# Altium schematic sheet CPU.SchDoc(CPU)
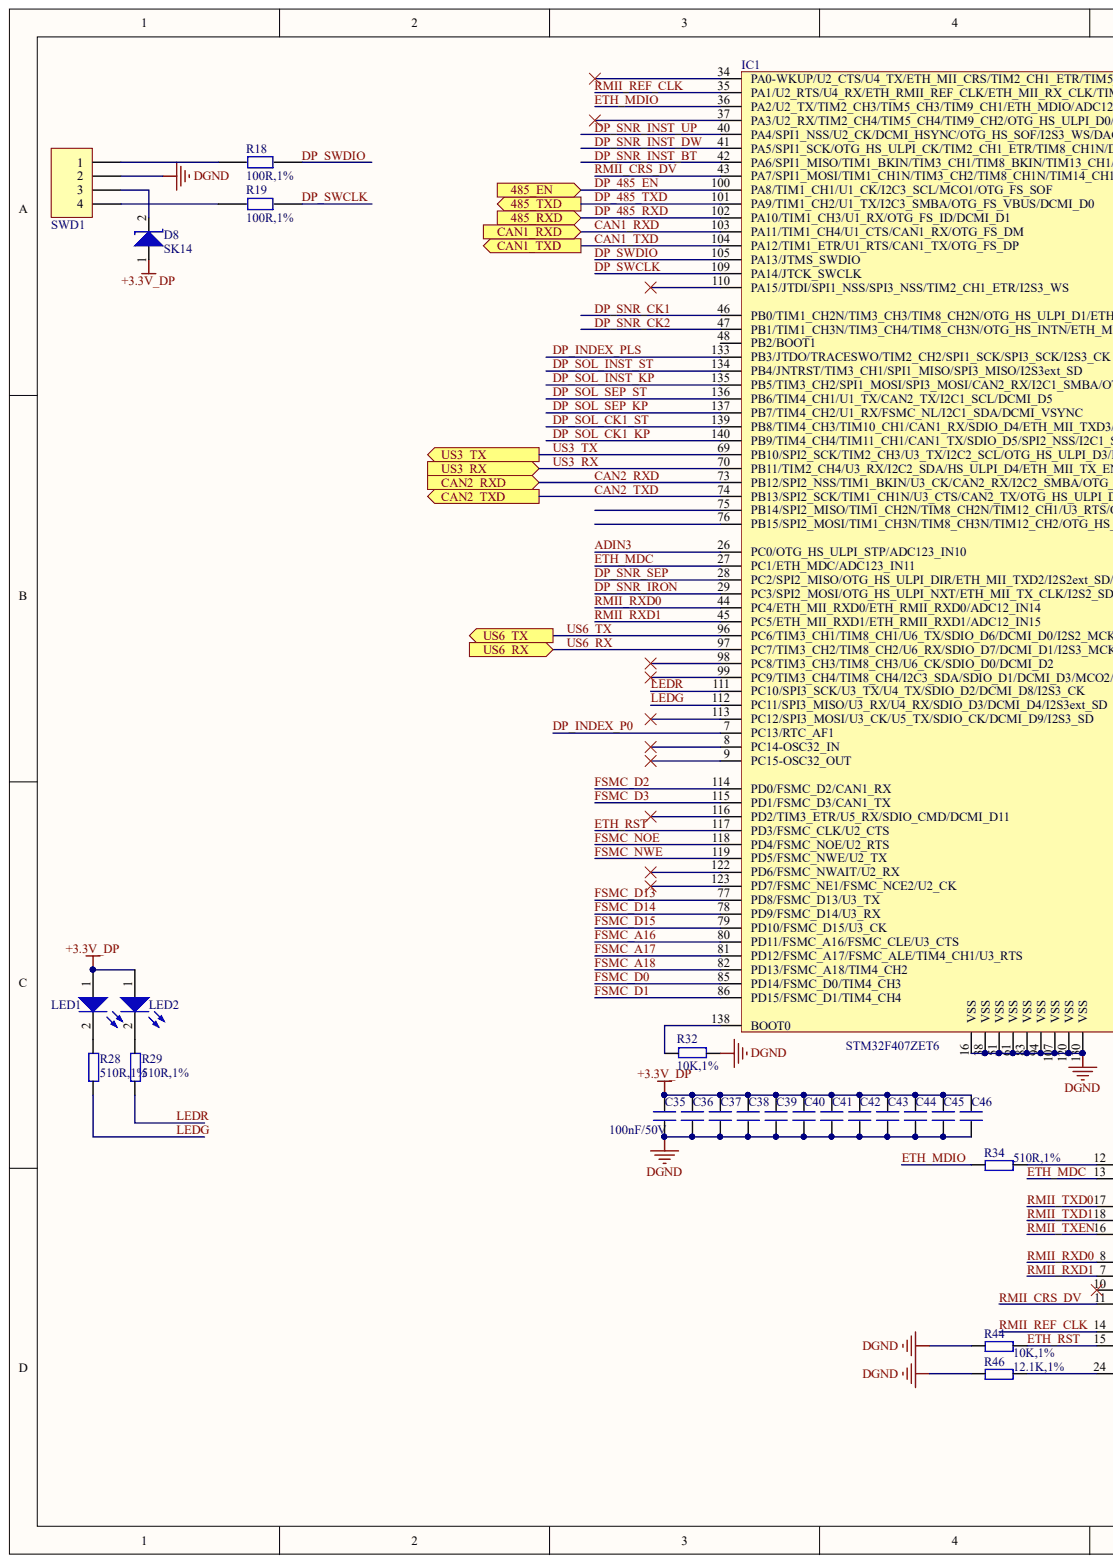
[diagram p0: CPU.SchDoc, left]
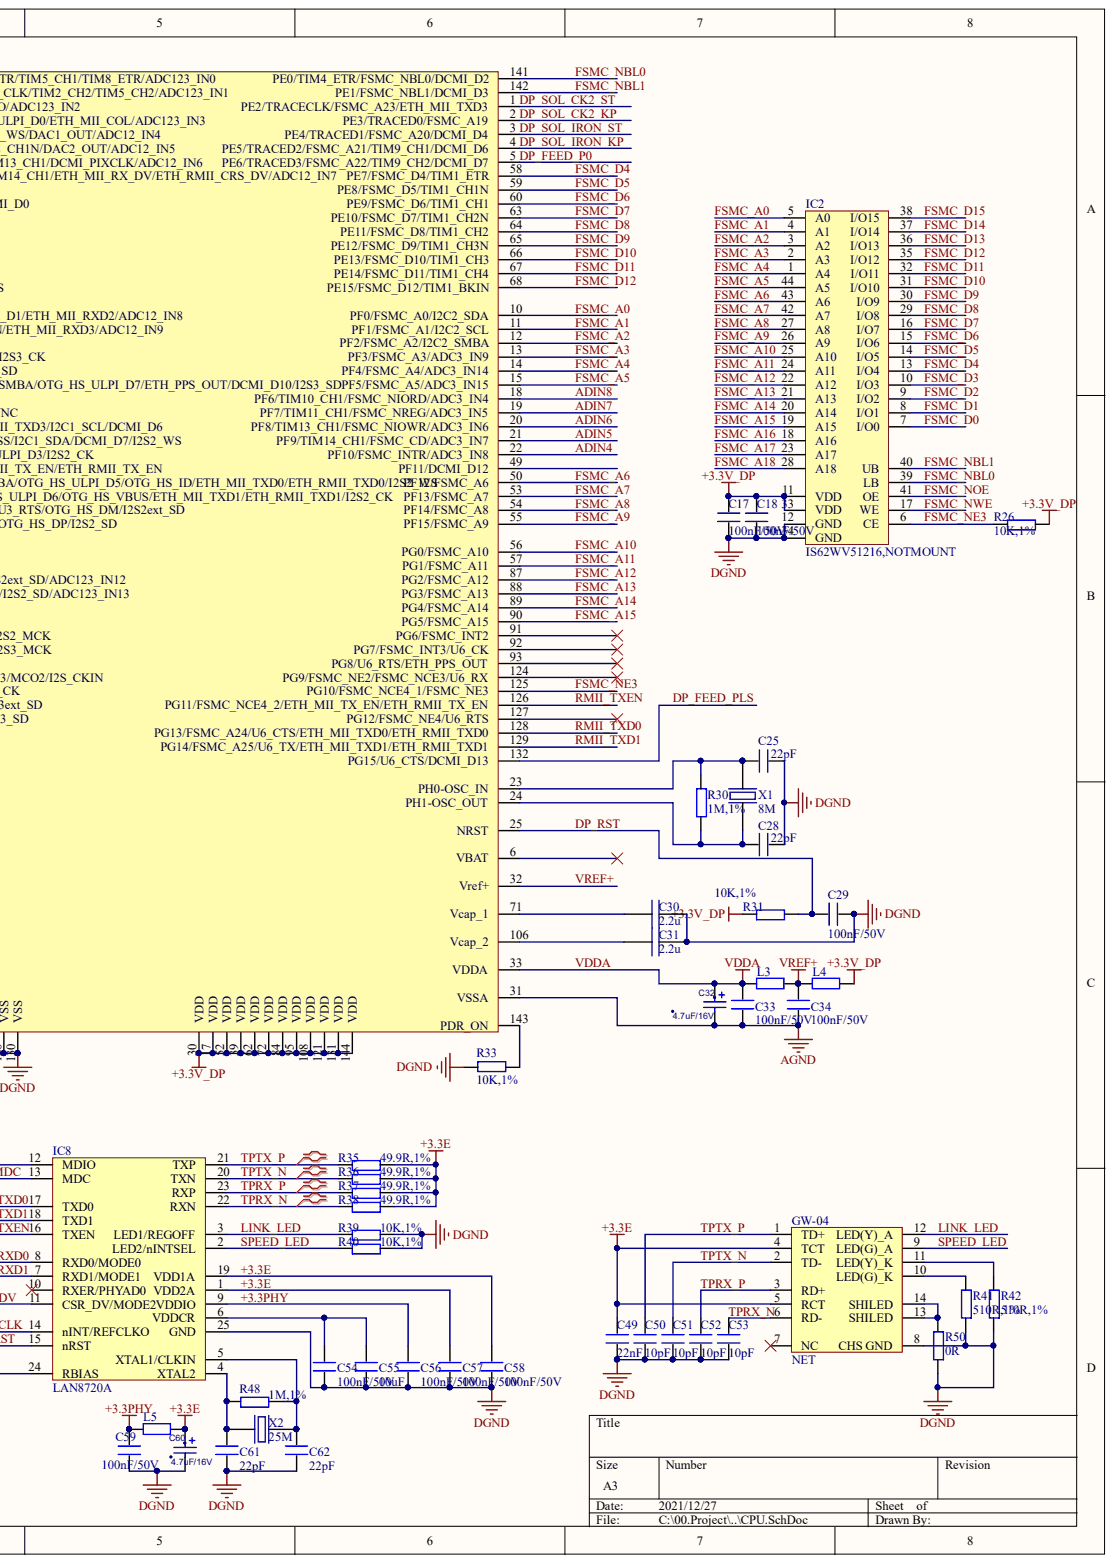
[diagram p1: CPU.SchDoc, right]

## Parts
C17  100nF/50V  [C0603]
C18  100nF/50V  [C0603]
C25  22pF  [C0603]
C28  22pF  [C0603]
C29  100nF/50V  [C0603]
C30  2.2u  [CAPC2012L]
C31  2.2u  [CAPC2012L]
C32  4.7uF/16V  [TCA3216]
C33  100nF/50V  [C0603]
C34  100nF/50V  [C0603]
C35  100nF/50V  [C0603]
C36  100nF/50V  [C0603]
C37  100nF/50V  [C0603]
C38  100nF/50V  [C0603]
C39  100nF/50V  [C0603]
C40  100nF/50V  [C0603]
C41  100nF/50V  [C0603]
C42  100nF/50V  [C0603]
C43  100nF/50V  [C0603]
C44  100nF/50V  [C0603]
C45  100nF/50V  [C0603]
C46  100nF/50V  [C0603]
C49  22nF  [C0603]
C50  10pF  [C0603]
C51  10pF  [C0603]
C52  10pF  [C0603]
C53  10pF  [C0603]
C54  100nF/50V  [C0603]
C55  10uF  [1210]
C56  100nF/50V  [C0603]
C57  100nF/50V  [C0603]
C58  100nF/50V  [C0603]
C59  100nF/50V  [C0603]
C60  4.7uF/16V  [TCA3216]
C61  22pF  [C0603]
C62  22pF  [C0603]
D8  SK14  [SMA/DO-214AC]
GW-04  NET  [HR911105A]
IC1  STM32F407ZET6  [TQFP144_M]
IC2  IS62WV51216,NOTMOUNT  [TSOP44]
IC8  LAN8720A  [QFN24]
L3  BLM21AG151SN1D  [CAPC2012L]  (value not drawn)
L4  BLM21AG151SN1D  [CAPC2012L]  (value not drawn)
L5  BLM21AG151SN1D  [CAPC2012L]  (value not drawn)
LED1  LED0603  [LED0603]  (value not drawn)
LED2  LED0603  [LED0603]  (value not drawn)
R18  100R,1%  [R0603]
R19  100R,1%  [R0603]
R26  10K,1%  [R0603]
R28  510R,1%  [R0603]
R29  510R,1%  [R0603]
R30  1M,1%  [R0603]
R31  10K,1%  [R0603]
R32  10K,1%  [R0603]
R33  10K,1%  [R0603]
R34  510R,1%  [R0603]
R35  49.9R,1%  [R0603]
R36  49.9R,1%  [R0603]
R37  49.9R,1%  [R0603]
R38  49.9R,1%  [R0603]
R39  10K,1%  [R0603]
R40  10K,1%  [R0603]
R41  510R,1%  [R0603]
R42  510R,1%  [R0603]
R44  10K,1%  [R0603]
R46  12.1K,1%  [R0603]
R48  1M,1%  [R0603]
R50  0R  [R0603]
SWD1  SWD  [HDR1X4]
X1  8M  [SMD5032]
X2  25M  [SMD5032]

## Ports
485_EN, 485_RXD, 485_TXD, CAN1_RXD, CAN1_TXD, CAN2_RXD, CAN2_TXD, US3_RX, US3_TX, US6_RX, US6_TX

## Nets
+3.3V_DP: C17.1 C18.1 C35.2 C36.2 C37.2 C38.2 C39.2 C40.2 C41.2 C42.2 C43.2 C44.2 C45.2 C46.2 D8.1 IC1.17(VDD) IC1.30(VDD) IC1.39(VDD) IC1.52(VDD) IC1.62(VDD) IC1.72(VDD) IC1.84(VDD) IC1.95(VDD) IC1.108(VDD) IC1.121(VDD) IC1.131(VDD) IC1.144(VDD) IC2.11(VDD) IC2.33(VDD) L4.2 LED1.1 LED2.1 R26.2 R31.1
AGND: C32.2 C33.1 C34.1 IC1.31(VSSA)
DGND: C17.2 C18.2 C25.1 C28.1 C29.1 C30.1 C31.1 C35.1 C36.1 C37.1 C38.1 C39.1 C40.1 C41.1 C42.1 C43.1 C44.1 C45.1 C46.1 C49.1 C50.1 C51.1 C52.1 C53.1 C54.1 C55.1 C56.1 C57.1 C58.1 C59.1 C60.2 C61.1 C62.1 GW-04.8(CHS_GND) IC1.16(VSS) IC1.38(VSS) IC1.51(VSS) IC1.61(VSS) IC1.83(VSS) IC1.94(VSS) IC1.107(VSS) IC1.120(VSS) IC1.130(VSS) IC2.12(GND) IC2.34(GND) IC8.25(GND) R32.1 R33.2 R39.2 R40.2 R41.1 R42.1 R44.1 R46.1 R50.1 SWD1.2
+3.3E: C49.2 C57.2 C58.2 C60.1 GW-04.4(TCT) GW-04.5(RCT) IC8.1(VDD2A) IC8.19(VDD1A) L5.2 R35.2 R36.2 R37.2 R38.2
+3.3PHY: C56.2 C59.2 IC8.9(VDDIO) L5.1
ADIN3: IC1.26(PC0/OTG_HS_ULPI_STP/ADC123_IN10)
ADIN4: IC1.22(PF10/FSMC_INTR/ADC3_IN8)
ADIN5: IC1.21(PF9/TIM14_CH1/FSMC_CD/ADC3_IN7)
ADIN6: IC1.20(PF8/TIM13_CH1/FSMC_NIOWR/ADC3_IN6)
ADIN7: IC1.19(PF7/TIM11_CH1/FSMC_NREG/ADC3_IN5)
ADIN8: IC1.18(PF6/TIM10_CH1/FSMC_NIORD/ADC3_IN4)
CAN1_RXD: IC1.103(PA11/TIM1_CH4/U1_CTS/CAN1_RX/OTG_FS_DM) ; port CAN1_RXD
CAN1_TXD: IC1.104(PA12/TIM1_ETR/U1_RTS/CAN1_TX/OTG_FS_DP) ; port CAN1_TXD
CAN2_RXD: IC1.73(PB12/SPI2_NSS/TIM1_BKIN/U3_CK/CAN2_RX/I2C2_SMBA/OTG_HS_ULPI_D5/OTG_HS_ID/ETH_MII_TXD0/ETH_RMII_TXD0/I2S2_WS) ; port CAN2_RXD
CAN2_TXD: IC1.74(PB13/SPI2_SCK/TIM1_CH1N/U3_CTS/CAN2_TX/OTG_HS_ULPI_D6/OTG_HS_VBUS/ETH_MII_TXD1/ETH_RMII_TXD1/I2S2_CK) ; port CAN2_TXD
DP_485_EN: IC1.100(PA8/TIM1_CH1/U1_CK/I2C3_SCL/MCO1/OTG_FS_SOF) ; port 485_EN
DP_485_RXD: IC1.102(PA10/TIM1_CH3/U1_RX/OTG_FS_ID/DCMI_D1) ; port 485_RXD
DP_485_TXD: IC1.101(PA9/TIM1_CH2/U1_TX/I2C3_SMBA/OTG_FS_VBUS/DCMI_D0) ; port 485_TXD
DP_FEED_P0: IC1.5(PE6/TRACED3/FSMC_A22/TIM9_CH2/DCMI_D7)
DP_FEED_PLS: IC1.132(PG15/U6_CTS/DCMI_D13)
DP_INDEX_P0: IC1.7(PC13/RTC_AF1)
DP_INDEX_PLS: IC1.133(PB3/JTDO/TRACESWO/TIM2_CH2/SPI1_SCK/SPI3_SCK/I2S3_CK)
DP_RST: C29.2 IC1.25(NRST) R31.2
DP_SNR_CK1: IC1.46(PB0/TIM1_CH2N/TIM3_CH3/TIM8_CH2N/OTG_HS_ULPI_D1/ETH_MII_RXD2/ADC12_IN8)
DP_SNR_CK2: IC1.47(PB1/TIM1_CH3N/TIM3_CH4/TIM8_CH3N/OTG_HS_INTN/ETH_MII_RXD3/ADC12_IN9)
DP_SNR_INST_BT: IC1.42(PA6/SPI1_MISO/TIM1_BKIN/TIM3_CH1/TIM8_BKIN/TIM13_CH1/DCMI_PIXCLK/ADC12_IN6)
DP_SNR_INST_DW: IC1.41(PA5/SPI1_SCK/OTG_HS_ULPI_CK/TIM2_CH1_ETR/TIM8_CH1N/DAC2_OUT/ADC12_IN5)
DP_SNR_INST_UP: IC1.40(PA4/SPI1_NSS/U2_CK/DCMI_HSYNC/OTG_HS_SOF/I2S3_WS/DAC1_OUT/ADC12_IN4)
DP_SNR_IRON: IC1.29(PC3/SPI2_MOSI/OTG_HS_ULPI_NXT/ETH_MII_TX_CLK/I2S2_SD/ADC123_IN13)
DP_SNR_SEP: IC1.28(PC2/SPI2_MISO/OTG_HS_ULPI_DIR/ETH_MII_TXD2/I2S2ext_SD/ADC123_IN12)
DP_SOL_CK1_KP: IC1.140(PB9/TIM4_CH4/TIM11_CH1/CAN1_TX/SDIO_D5/SPI2_NSS/I2C1_SDA/DCMI_D7/I2S2_WS)
DP_SOL_CK1_ST: IC1.139(PB8/TIM4_CH3/TIM10_CH1/CAN1_RX/SDIO_D4/ETH_MII_TXD3/I2C1_SCL/DCMI_D6)
DP_SOL_CK2_KP: IC1.2(PE3/TRACED0/FSMC_A19)
DP_SOL_CK2_ST: IC1.1(PE2/TRACECLK/FSMC_A23/ETH_MII_TXD3)
DP_SOL_INST_KP: IC1.135(PB5/TIM3_CH2/SPI1_MOSI/SPI3_MOSI/CAN2_RX/I2C1_SMBA/OTG_HS_ULPI_D7/ETH_PPS_OUT/DCMI_D10/I2S3_SD)
DP_SOL_INST_ST: IC1.134(PB4/JNTRST/TIM3_CH1/SPI1_MISO/SPI3_MISO/I2S3ext_SD)
DP_SOL_IRON_KP: IC1.4(PE5/TRACED2/FSMC_A21/TIM9_CH1/DCMI_D6)
DP_SOL_IRON_ST: IC1.3(PE4/TRACED1/FSMC_A20/DCMI_D4)
DP_SOL_SEP_KP: IC1.137(PB7/TIM4_CH2/U1_RX/FSMC_NL/I2C1_SDA/DCMI_VSYNC)
DP_SOL_SEP_ST: IC1.136(PB6/TIM4_CH1/U1_TX/CAN2_TX/I2C1_SCL/DCMI_D5)
DP_SWCLK: IC1.109(PA14/JTCK_SWCLK) R19.2
DP_SWDIO: IC1.105(PA13/JTMS_SWDIO) R18.2
ETH_MDC: IC1.27(PC1/ETH_MDC/ADC123_IN11) IC8.13(MDC)
ETH_MDIO: IC1.36(PA2/U2_TX/TIM2_CH3/TIM5_CH3/TIM9_CH1/ETH_MDIO/ADC123_IN2) R34.1
ETH_RST: IC1.117(PD3/FSMC_CLK/U2_CTS) IC8.15(nRST) R44.2
FSMC_A0: IC1.10(PF0/FSMC_A0/I2C2_SDA) IC2.5(A0)
FSMC_A1: IC1.11(PF1/FSMC_A1/I2C2_SCL) IC2.4(A1)
FSMC_A2: IC1.12(PF2/FSMC_A2/I2C2_SMBA) IC2.3(A2)
FSMC_A3: IC1.13(PF3/FSMC_A3/ADC3_IN9) IC2.2(A3)
FSMC_A4: IC1.14(PF4/FSMC_A4/ADC3_IN14) IC2.1(A4)
FSMC_A5: IC1.15(PF5/FSMC_A5/ADC3_IN15) IC2.44(A5)
FSMC_A6: IC1.50(PF12/FSMC_A6) IC2.43(A6)
FSMC_A7: IC1.53(PF13/FSMC_A7) IC2.42(A7)
FSMC_A8: IC1.54(PF14/FSMC_A8) IC2.27(A8)
FSMC_A9: IC1.55(PF15/FSMC_A9) IC2.26(A9)
FSMC_A10: IC1.56(PG0/FSMC_A10) IC2.25(A10)
FSMC_A11: IC1.57(PG1/FSMC_A11) IC2.24(A11)
FSMC_A12: IC1.87(PG2/FSMC_A12) IC2.22(A12)
FSMC_A13: IC1.88(PG3/FSMC_A13) IC2.21(A13)
FSMC_A14: IC1.89(PG4/FSMC_A14) IC2.20(A14)
FSMC_A15: IC1.90(PG5/FSMC_A15) IC2.19(A15)
FSMC_A16: IC1.80(PD11/FSMC_A16/FSMC_CLE/U3_CTS) IC2.18(A16)
FSMC_A17: IC1.81(PD12/FSMC_A17/FSMC_ALE/TIM4_CH1/U3_RTS) IC2.23(A17)
FSMC_A18: IC1.82(PD13/FSMC_A18/TIM4_CH2) IC2.28(A18)
FSMC_D0: IC1.85(PD14/FSMC_D0/TIM4_CH3) IC2.7(I/O0)
FSMC_D1: IC1.86(PD15/FSMC_D1/TIM4_CH4) IC2.8(I/O1)
FSMC_D2: IC1.114(PD0/FSMC_D2/CAN1_RX) IC2.9(I/O2)
FSMC_D3: IC1.115(PD1/FSMC_D3/CAN1_TX) IC2.10(I/O3)
FSMC_D4: IC1.58(PE7/FSMC_D4/TIM1_ETR) IC2.13(I/O4)
FSMC_D5: IC1.59(PE8/FSMC_D5/TIM1_CH1N) IC2.14(I/O5)
FSMC_D6: IC1.60(PE9/FSMC_D6/TIM1_CH1) IC2.15(I/O6)
FSMC_D7: IC1.63(PE10/FSMC_D7/TIM1_CH2N) IC2.16(I/O7)
FSMC_D8: IC1.64(PE11/FSMC_D8/TIM1_CH2) IC2.29(I/O8)
FSMC_D9: IC1.65(PE12/FSMC_D9/TIM1_CH3N) IC2.30(I/O9)
FSMC_D10: IC1.66(PE13/FSMC_D10/TIM1_CH3) IC2.31(I/O10)
FSMC_D11: IC1.67(PE14/FSMC_D11/TIM1_CH4) IC2.32(I/O11)
FSMC_D12: IC1.68(PE15/FSMC_D12/TIM1_BKIN) IC2.35(I/O12)
FSMC_D13: IC1.77(PD8/FSMC_D13/U3_TX) IC2.36(I/O13)
FSMC_D14: IC1.78(PD9/FSMC_D14/U3_RX) IC2.37(I/O14)
FSMC_D15: IC1.79(PD10/FSMC_D15/U3_CK) IC2.38(I/O15)
FSMC_NBL0: IC1.141(PE0/TIM4_ETR/FSMC_NBL0/DCMI_D2) IC2.39(LB)
FSMC_NBL1: IC1.142(PE1/FSMC_NBL1/DCMI_D3) IC2.40(UB)
FSMC_NE3: IC1.125(PG10/FSMC_NCE4_1/FSMC_NE3) IC2.6(CE) R26.1
FSMC_NOE: IC1.118(PD4/FSMC_NOE/U2_RTS) IC2.41(OE)
FSMC_NWE: IC1.119(PD5/FSMC_NWE/U2_TX) IC2.17(WE)
LEDG: IC1.112(PC11/SPI3_MISO/U3_RX/U4_RX/SDIO_D3/DCMI_D4/I2S3ext_SD) R28.1
LEDR: IC1.111(PC10/SPI3_SCK/U3_TX/U4_TX/SDIO_D2/DCMI_D8/I2S3_CK) R29.1
LINK_LED: GW-04.12(LED(Y)_A) IC8.3(LED1/REGOFF) R39.1
RMII_CRS_DV: IC1.43(PA7/SPI1_MOSI/TIM1_CH1N/TIM3_CH2/TIM8_CH1N/TIM14_CH1/ETH_MII_RX_DV/ETH_RMII_CRS_DV/ADC12_IN7) IC8.11(CSR_DV/MODE2)
RMII_REF_CLK: IC1.35(PA1/U2_RTS/U4_RX/ETH_RMII_REF_CLK/ETH_MII_RX_CLK/TIM2_CH2/TIM5_CH2/ADC123_IN1) IC8.14(nINT/REFCLKO)
RMII_RXD0: IC1.44(PC4/ETH_MII_RXD0/ETH_RMII_RXD0/ADC12_IN14) IC8.8(RXD0/MODE0)
RMII_RXD1: IC1.45(PC5/ETH_MII_RXD1/ETH_RMII_RXD1/ADC12_IN15) IC8.7(RXD1/MODE1)
RMII_TXD0: IC1.128(PG13/FSMC_A24/U6_CTS/ETH_MII_TXD0/ETH_RMII_TXD0) IC8.17(TXD0)
RMII_TXD1: IC1.129(PG14/FSMC_A25/U6_TX/ETH_MII_TXD1/ETH_RMII_TXD1) IC8.18(TXD1)
RMII_TXEN: IC1.126(PG11/FSMC_NCE4_2/ETH_MII_TX_EN/ETH_RMII_TX_EN) IC8.16(TXEN)
SPEED_LED: GW-04.9(LED(G)_A) IC8.2(LED2/nINTSEL) R40.1
TPRX_N: C53.2 GW-04.6(RD-) IC8.22(RXN) R38.1
TPRX_P: C52.2 GW-04.3(RD+) IC8.23(RXP) R37.1
TPTX_N: C51.2 GW-04.2(TD-) IC8.20(TXN) R36.1
TPTX_P: C50.2 GW-04.1(TD+) IC8.21(TXP) R35.1
US3_RX: IC1.70(PB11/TIM2_CH4/U3_RX/I2C2_SDA/HS_ULPI_D4/ETH_MII_TX_EN/ETH_RMII_TX_EN) ; port US3_RX
US3_TX: IC1.69(PB10/SPI2_SCK/TIM2_CH3/U3_TX/I2C2_SCL/OTG_HS_ULPI_D3/I2S2_CK) ; port US3_TX
US6_RX: IC1.97(PC7/TIM3_CH2/TIM8_CH2/U6_RX/SDIO_D7/DCMI_D1/I2S3_MCK) ; port US6_RX
US6_TX: IC1.96(PC6/TIM3_CH1/TIM8_CH1/U6_TX/SDIO_D6/DCMI_D0/I2S2_MCK) ; port US6_TX
VDDA: C32.1 C33.2 IC1.33(VDDA) L3.1
VREF+: C34.2 IC1.32(Vref+) L3.2 L4.1
NetC25_2: C25.2 IC1.23(PH0-OSC_IN) R30.2 X1.2
NetC28_2: C28.2 IC1.24(PH1-OSC_OUT) R30.1 X1.1
NetC30_2: C30.2 IC1.71(Vcap_1)
NetC31_2: C31.2 IC1.106(Vcap_2)
NetC54_2: C54.2 C55.2 IC8.6(VDDCR)
NetC61_2: C61.2 IC8.4(XTAL2) R48.1 X2.2
NetC62_2: C62.2 IC8.5(XTAL1/CLKIN) R48.2 X2.1
NetD8_2: D8.2 SWD1.3
NetGW-04_10: GW-04.10(LED(G)_K) R41.2
NetGW-04_11: GW-04.11(LED(Y)_K) R42.2
NetGW-04_13: GW-04.13(SHILED) GW-04.14(SHILED) R50.2
NetIC1_138: IC1.138(BOOT0) R32.2
NetIC1_143: IC1.143(PDR_ON) R33.1
NetIC8_12: IC8.12(MDIO) R34.2
NetIC8_24: IC8.24(RBIAS) R46.2
NetLED1_2: LED1.2 R28.2
NetLED2_2: LED2.2 R29.2
NetR18_1: R18.1 SWD1.1
NetR19_1: R19.1 SWD1.4

Not connected: GW-04 7(NC); IC1 6(VBAT) 8(PC14-OSC32_IN) 9(PC15-OSC32_OUT) 34(PA0-WKUP/U2_CTS/U4_TX/ETH_MII_CRS/TIM2_CH1_ETR/TIM5_CH1/TIM8_ETR/ADC123_IN0) 37(PA3/U2_RX/TIM2_CH4/TIM5_CH4/TIM9_CH2/OTG_HS_ULPI_D0/ETH_MII_COL/ADC123_IN3) 48(PB2/BOOT1) 49(PF11/DCMI_D12) 75(PB14/SPI2_MISO/TIM1_CH2N/TIM8_CH2N/TIM12_CH1/U3_RTS/OTG_HS_DM/I2S2ext_SD) 76(PB15/SPI2_MOSI/TIM1_CH3N/TIM8_CH3N/TIM12_CH2/OTG_HS_DP/I2S2_SD) 91(PG6/FSMC_INT2) 92(PG7/FSMC_INT3/U6_CK) 93(PG8/U6_RTS/ETH_PPS_OUT) 98(PC8/TIM3_CH3/TIM8_CH3/U6_CK/SDIO_D0/DCMI_D2) 99(PC9/TIM3_CH4/TIM8_CH4/I2C3_SDA/SDIO_D1/DCMI_D3/MCO2/I2S_CKIN) 110(PA15/JTDI/SPI1_NSS/SPI3_NSS/TIM2_CH1_ETR/I2S3_WS) 113(PC12/SPI3_MOSI/U3_CK/U5_TX/SDIO_CK/DCMI_D9/I2S3_SD) 116(PD2/TIM3_ETR/U5_RX/SDIO_CMD/DCMI_D11) 122(PD6/FSMC_NWAIT/U2_RX) 123(PD7/FSMC_NE1/FSMC_NCE2/U2_CK) 124(PG9/FSMC_NE2/FSMC_NCE3/U6_RX) 127(PG12/FSMC_NE4/U6_RTS); IC8 10(RXER/PHYAD0)

## Library data of the parts
C17 C18 C25 C28 C29 C33-C46 C49-C59 C61 C62 = C: Component Kind: Standard; Library Name: IMX6UL_ALPHA(底板)_V2.0.IntLib
C30 C31 = CAP: Component Kind: Standard; Library Name: ZYFShLib.SchLib
C32 C60 = TCA3216: ROOM: LM3S5P36; 物?: 102030236; : 电容,(贴片),18pF/50V,±5%,0805,COG; Component Kind: Standard; Library Name: mypcb.SCHLIB
D8 = D_SK14_SMA/DO-214AC: 40V/1A,Surface Mount Schottky Barrier Recifier; Manufacturer: 锢锝; If: 1A; PCB Footprint: SMA; Vrms: 28V; Component Kind: Standard; Value: SK14; Library Name: Common_YSD.SchLib
GW-04 = HR911105: Component Kind: Standard; Library Name: ENC28J60.SCHLIB
IC1 = STM32F407ZE: Component Kind: Standard; Description: STM32F407ZET6; Library Name: Explorer STM32F407封装库.IntLib
IC2 = IS62WV51216: Component Kind: Standard; Library Name: Explorer STM32F407封装库.IntLib
IC8 = LAN8720: ETH PHY; Component Kind: Standard; Library Name: IMX6UL_ALPHA(底板)_V2.0.IntLib
L3-L5 = Res2: Resistor; Value: 1K; Component Kind: Standard; LatestRevisionDate: 17-Jul-2002; LatestRevisionNote: Re-released for DXP Platform.; Library Name: Miscellaneous Devices.IntLib; PackageReference: AXIAL-0.4; Published: 8-Jun-2000; Signal Integrity: Res; Simulation: RESISTOR; Publisher: Altium Limited
LED1 LED2 = LED0603: LED灯 0603; Value: 红; Component Kind: Standard; PCB Footprint: LED0603; Library Name: Common_YSD.SchLib
R18 R19 = RES: Component Kind: Standard; Library Name: ZYFShLib.SchLib
R26 R28-R42 R44 R46 R48 R50 = R: Component Kind: Standard; Library Name: IMX6UL_ALPHA(底板)_V2.0.IntLib
SWD1 = Header 4_2.54: Component Kind: Standard; Library Name: mypcb.SCHLIB
X1 X2 = XTAL: Component Kind: Standard; Library Name: ZYFShLib.SchLib
